# Altium schematic sheet Power-Supplies.SchDoc(Power-Supplies)
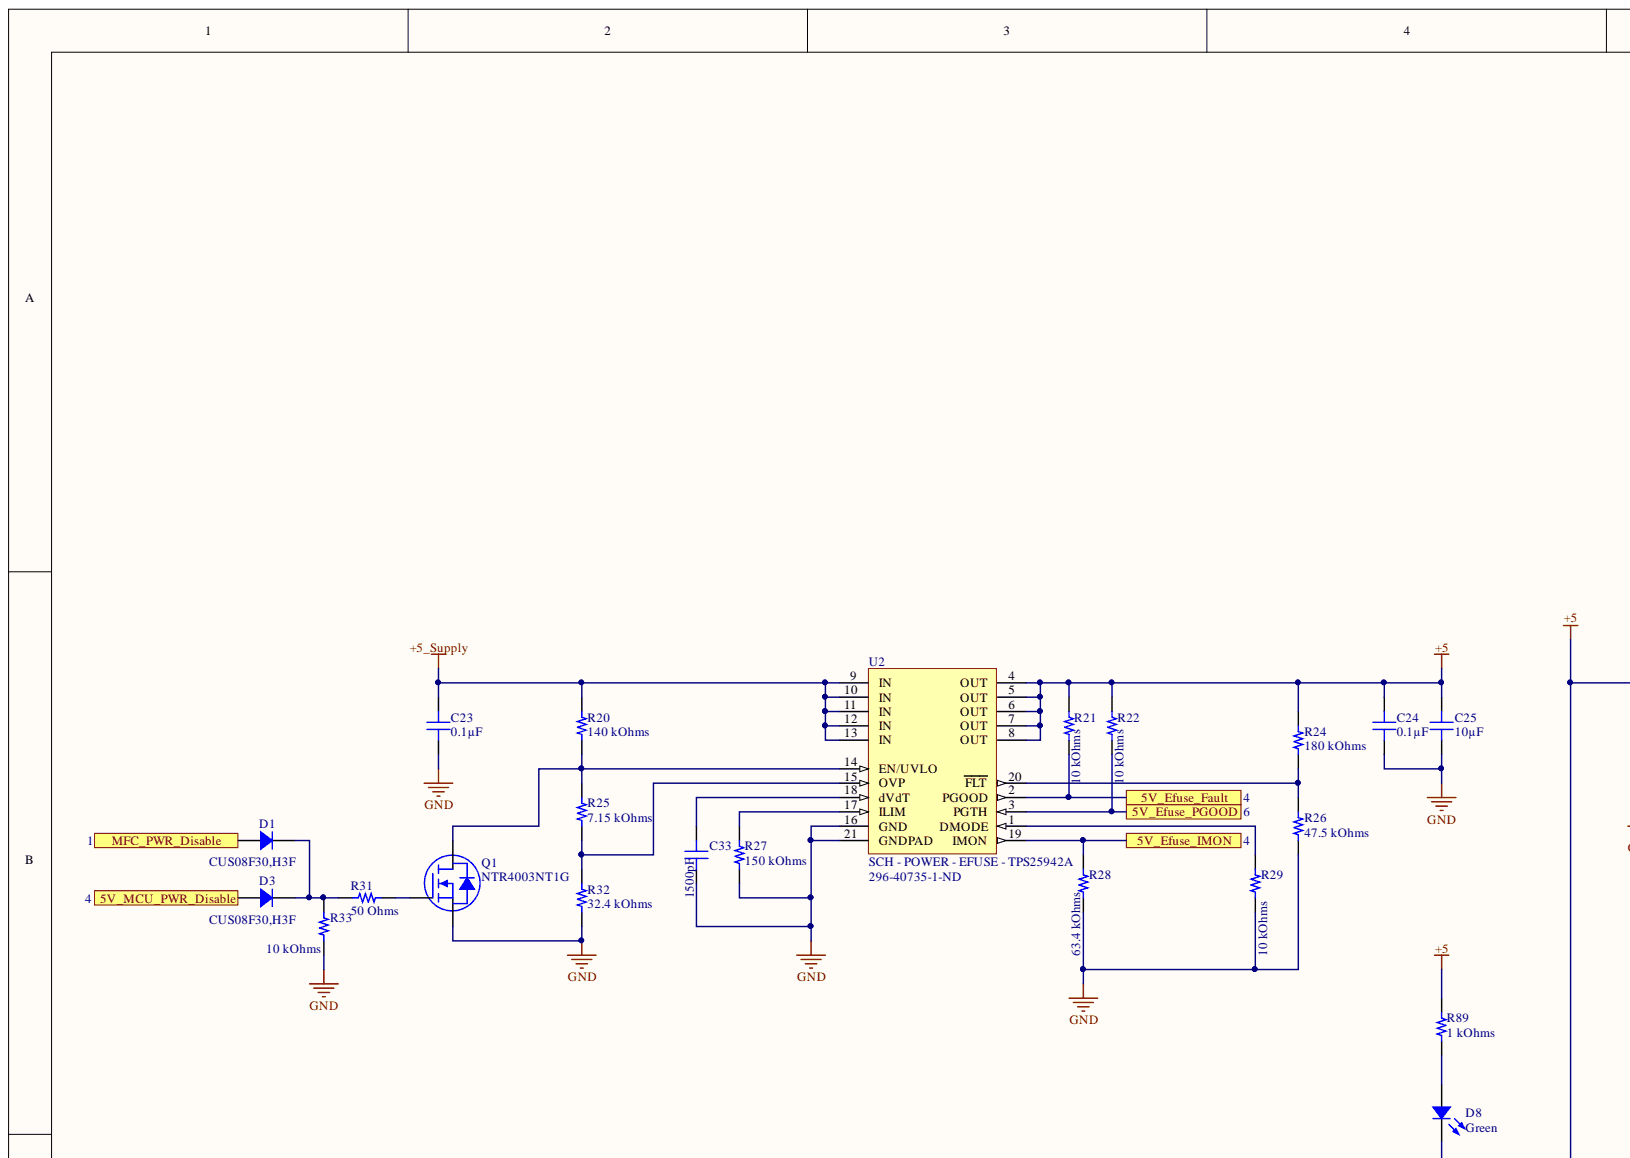
[diagram p0: Power-Supplies.SchDoc, top left]
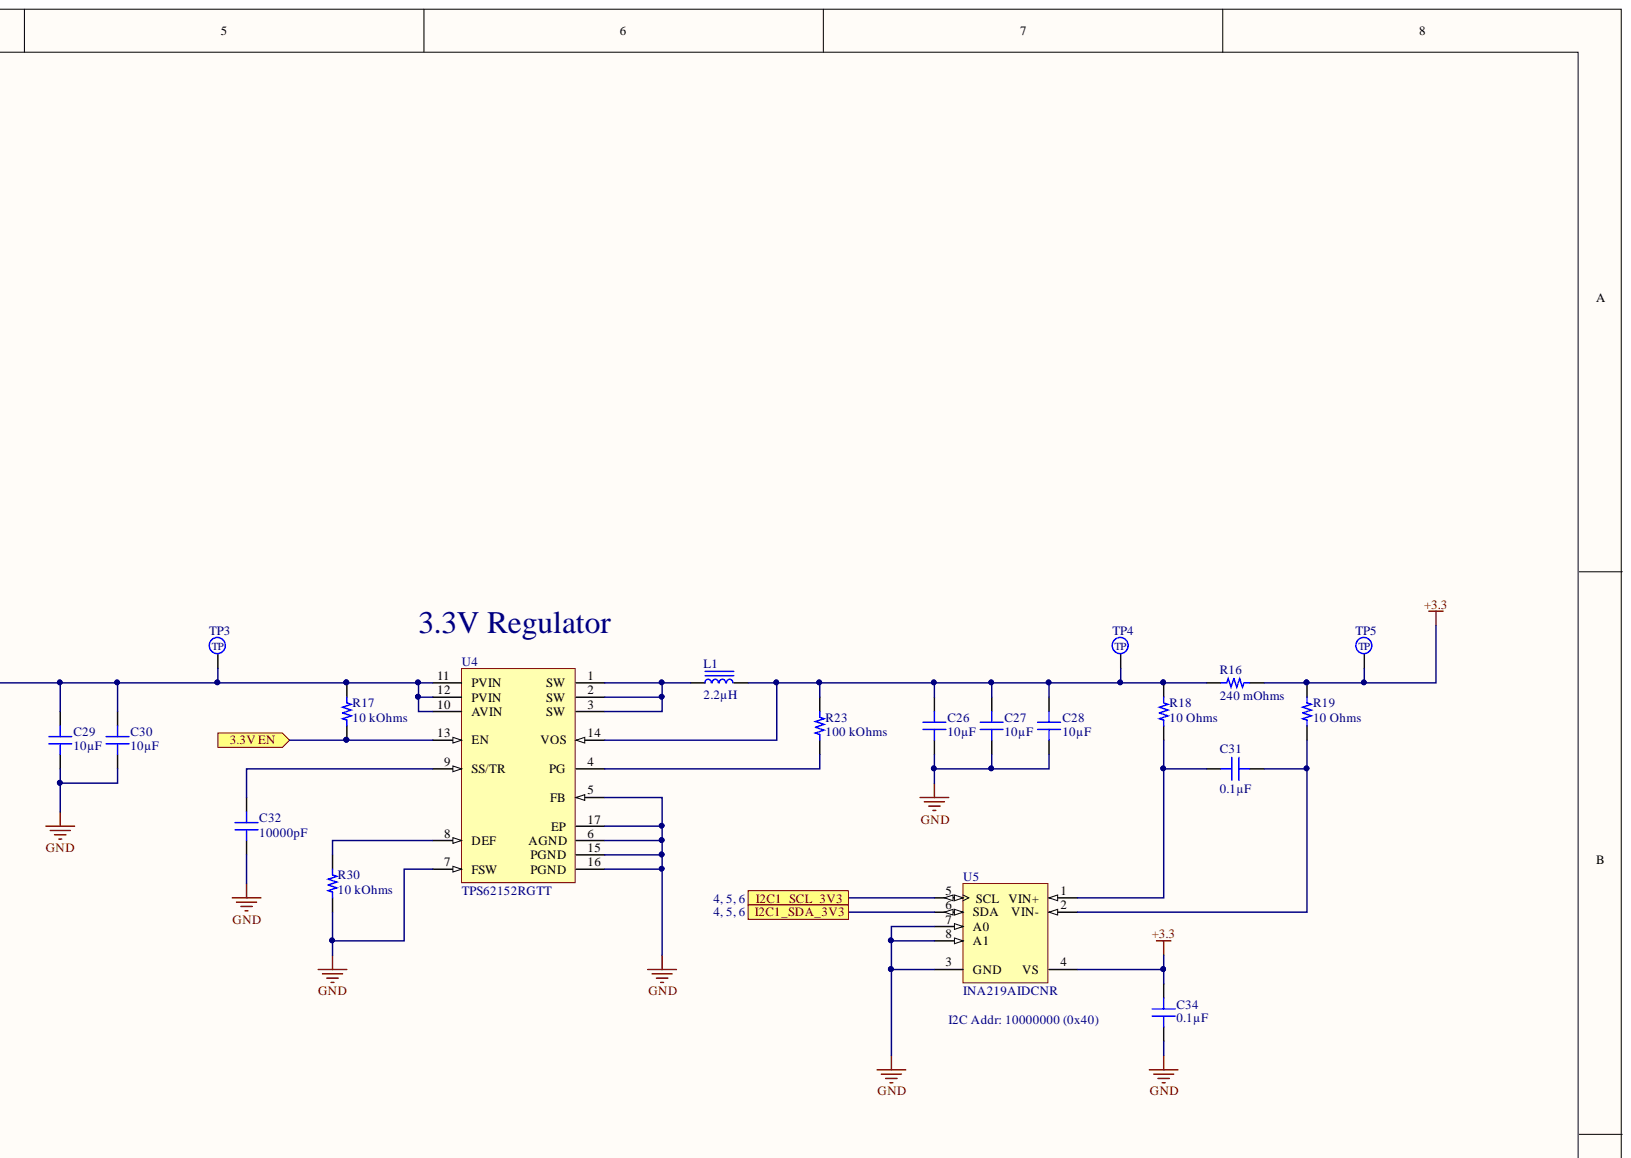
[diagram p1: Power-Supplies.SchDoc, top right]
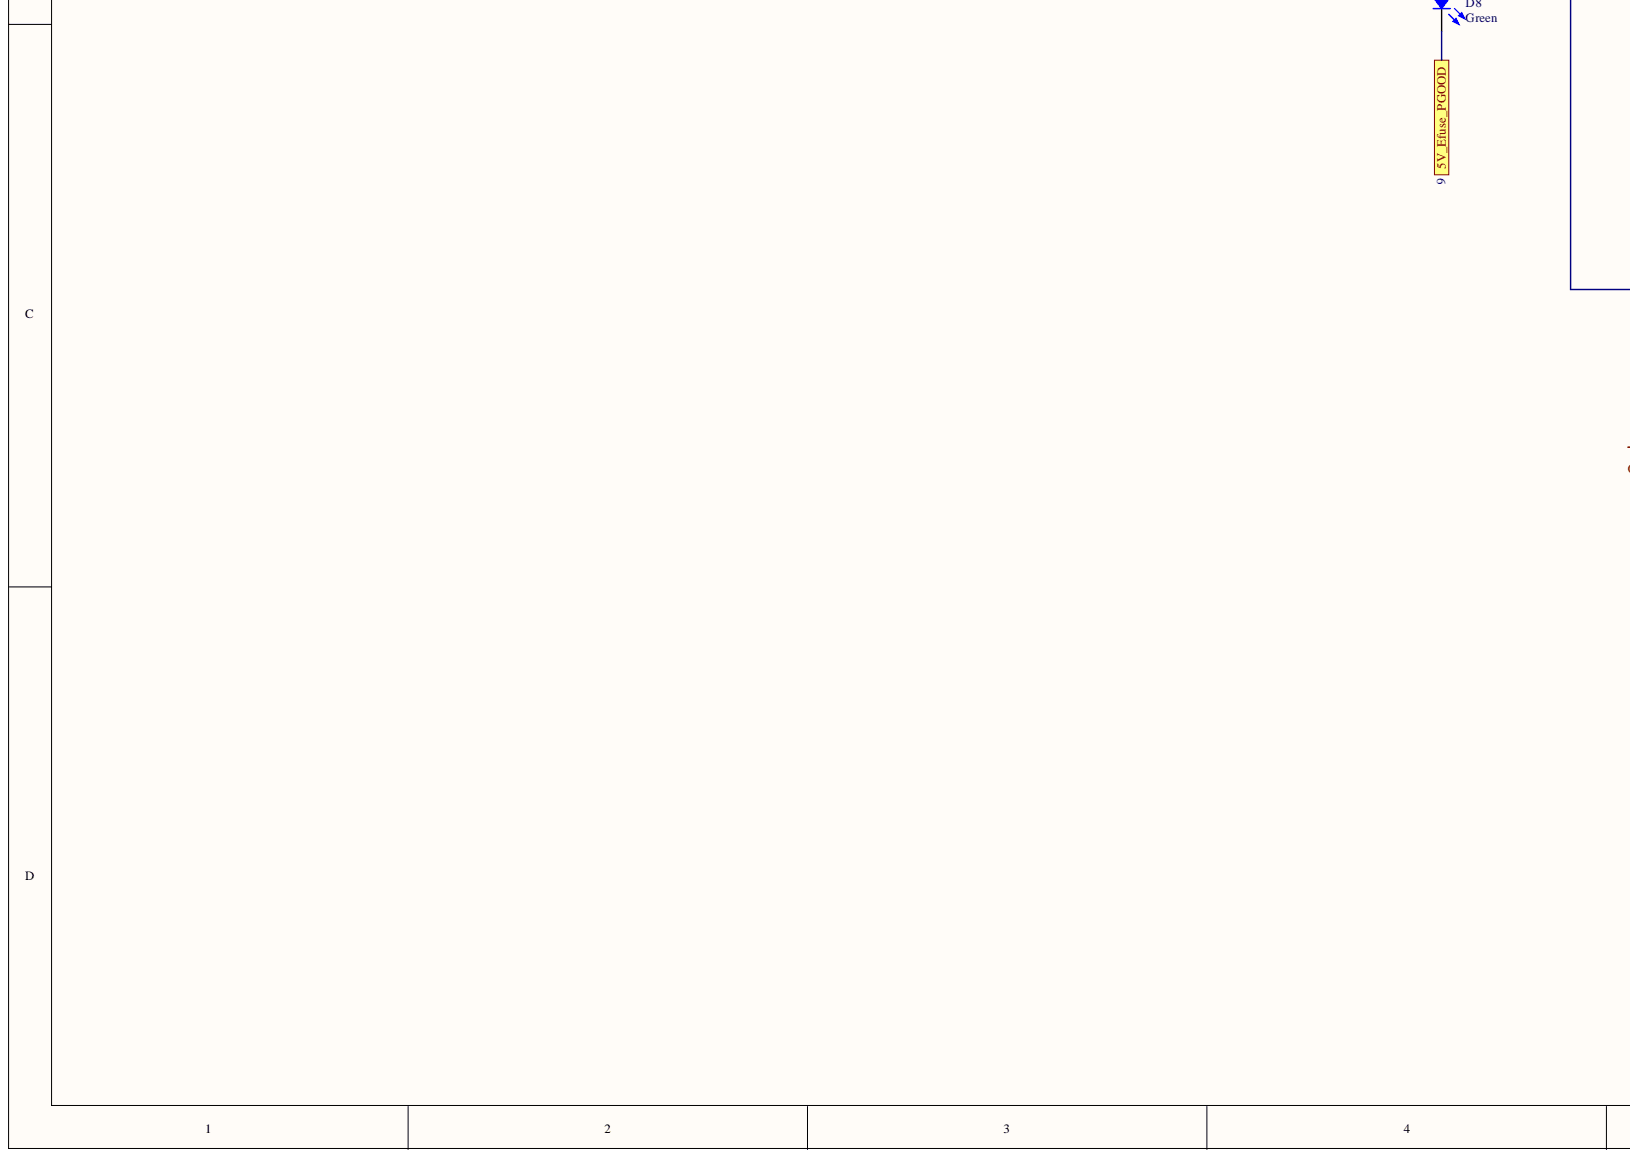
[diagram p2: Power-Supplies.SchDoc, bottom left]
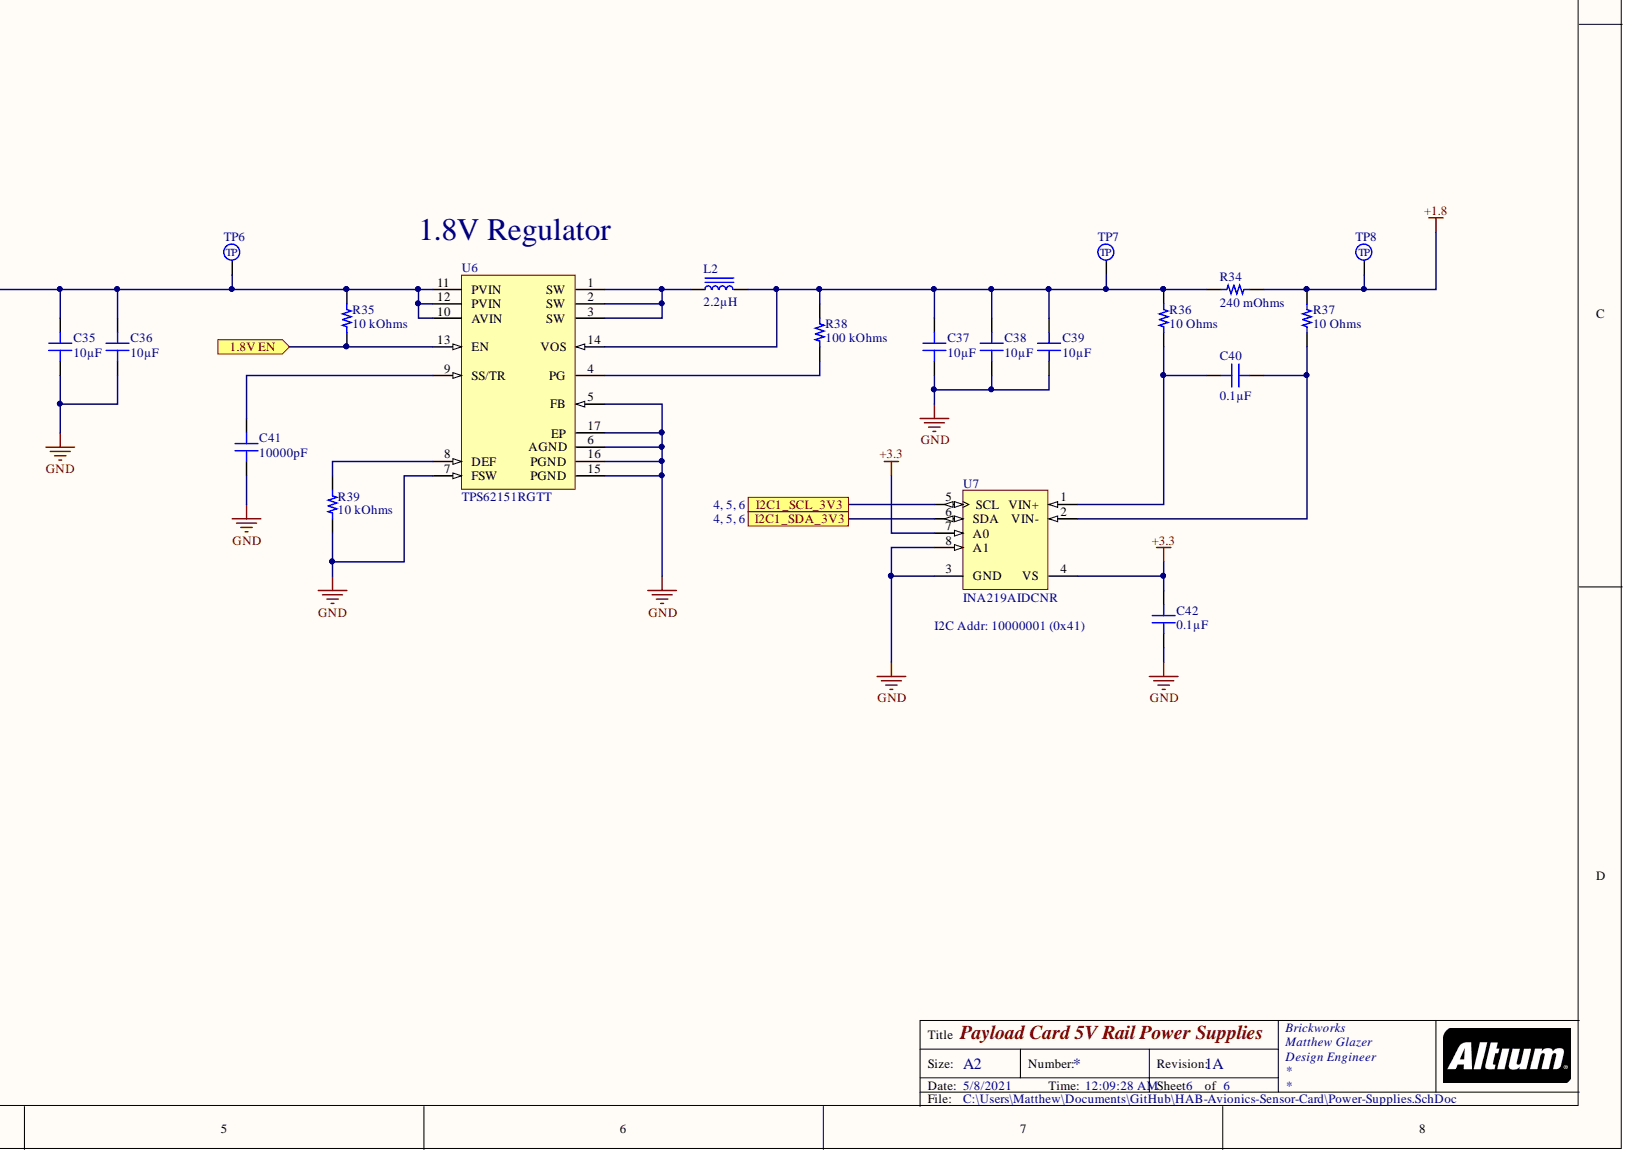
[diagram p3: Power-Supplies.SchDoc, bottom right]

## Parts
C23  0.1µF  [CAP 0603_1608]
C24  0.1µF  [CAP 0603_1608]
C25  10µF  [CAP 0805_2012]
C26  10µF  [CAP 0805_2012]
C27  10µF  [CAP 0805_2012]
C28  10µF  [CAP 0805_2012]
C29  10µF  [CAP 0805_2012]
C30  10µF  [CAP 0805_2012]
C31  0.1µF  [CAP 0603_1608]
C32  10000pF  [CAP 0603_1608]
C33  1500pF  [CAP 0603_1608]
C34  0.1µF  [CAP 0603_1608]
C35  10µF  [CAP 0805_2012]
C36  10µF  [CAP 0805_2012]
C37  10µF  [CAP 0805_2012]
C38  10µF  [CAP 0805_2012]
C39  10µF  [CAP 0805_2012]
C40  0.1µF  [CAP 0603_1608]
C41  10000pF  [CAP 0603_1608]
C42  0.1µF  [CAP 0603_1608]
D1  CUS08F30,H3F  [SOD323]
D3  CUS08F30,H3F  [SOD323]
D8  Green  [LED 0603_1608 GREEN]
L1  2.2µH  [BOURNS SRN4018]
L2  2.2µH  [BOURNS SRN4018]
Q1  NTR4003NT1G  [ON SEMI SOT-23-3]
R16  240 mOhms  [RES 0805_2012]
R17  10 kOhms  [RES 0603_1608]
R18  10 Ohms  [RES 0603_1608]
R19  10 Ohms  [RES 0603_1608]
R20  140 kOhms  [RES 0603_1608]
R21  10 kOhms  [RES 0603_1608]
R22  10 kOhms  [RES 0603_1608]
R23  100 kOhms  [RES 0603_1608]
R24  180 kOhms  [RES 0603_1608]
R25  7.15 kOhms  [RES 0603_1608]
R26  47.5 kOhms  [RES 0603_1608]
R27  150 kOhms  [RES 0603_1608]
R28  63.4 kOhms  [RES 0603_1608]
R29  10 kOhms  [RES 0603_1608]
R30  10 kOhms  [RES 0603_1608]
R31  50 Ohms  [RES 0603_1608]
R32  32.4 kOhms  [RES 0603_1608]
R33  10 kOhms  [RES 0603_1608]
R34  240 mOhms  [RES 0805_2012]
R35  10 kOhms  [RES 0603_1608]
R36  10 Ohms  [RES 0603_1608]
R37  10 Ohms  [RES 0603_1608]
R38  100 kOhms  [RES 0603_1608]
R39  10 kOhms  [RES 0603_1608]
R89  1 kOhms  [RES 0603_1608]
TP3  2.5mm_SMD_TestPoint  [2.5mm TestPoint]  (value not drawn)
TP4  2.5mm_SMD_TestPoint  [2.5mm TestPoint]  (value not drawn)
TP5  2.5mm_SMD_TestPoint  [2.5mm TestPoint]  (value not drawn)
TP6  2.5mm_SMD_TestPoint  [2.5mm TestPoint]  (value not drawn)
TP7  2.5mm_SMD_TestPoint  [2.5mm TestPoint]  (value not drawn)
TP8  2.5mm_SMD_TestPoint  [2.5mm TestPoint]  (value not drawn)
U2  SCH - POWER - EFUSE - TPS25942A  Supplier Part Number 1: 296-40735-1-ND  [PCB - LEADLESS - QFN - TI 20-WQFN]
U4  TPS62152RGTT  [RGT0016C_V]
U5  INA219AIDCNR  [DCN0008A_M]
U6  TPS62151RGTT  [RGT0016C_V]
U7  INA219AIDCNR  [DCN0008A_M]

## Ports
1.8V EN, 3.3V EN, 5V_Efuse_Fault, 5V_Efuse_IMON, 5V_Efuse_PGOOD, 5V_MCU_PWR_Disable, I2C1_SCL_3V3, I2C1_SDA_3V3, MFC_PWR_Disable

## Nets
+1.8: R34.2 R37.2 TP8.1
+3.3: C34.2 C42.2 R16.2 R19.2 TP5.1 U5.4(VS) U7.4(VS) U7.7(A0)
+5: C24.2 C25.2 C29.2 C30.2 C35.2 C36.2 R17.2 R21.2 R22.2 R24.2 R35.2 R89.1 TP3.1 TP6.1 U2.4(OUT) U2.5(OUT) U2.6(OUT) U2.7(OUT) U2.8(OUT) U4.10(AVIN) U4.11(PVIN) U4.12(PVIN) U6.10(AVIN) U6.11(PVIN) U6.12(PVIN)
+5_Supply: C23.2 R20.2 U2.9(IN) U2.10(IN) U2.11(IN) U2.12(IN) U2.13(IN)
GND: C23.1 C24.1 C25.1 C26.1 C27.1 C28.1 C29.1 C30.1 C32.1 C33.1 C34.1 C35.1 C36.1 C37.1 C38.1 C39.1 C41.1 C42.1 Q1.2 R26.1 R27.1 R28.1 R29.1 R30.1 R32.1 R33.1 R39.1 U2.16(GND) U2.21(GNDPAD) U4.5(FB) U4.6(AGND) U4.7(FSW) U4.15(PGND) U4.16(PGND) U4.17(EP) U5.3(GND) U5.7(A0) U5.8(A1) U6.5(FB) U6.6(AGND) U6.7(FSW) U6.15(PGND) U6.16(PGND) U6.17(EP) U7.3(GND) U7.8(A1)
1.8V EN: R35.1 U6.13(EN) ; port 1.8V EN
3.3V EN: R17.1 U4.13(EN) ; port 3.3V EN
5V_Efuse_Fault: R21.1 U2.2(PGOOD) ; port 5V_Efuse_Fault
5V_Efuse_IMON: R28.2 U2.19(IMON) ; port 5V_Efuse_IMON
5V_Efuse_PGOOD: D8.2 R22.1 U2.3(PGTH) ; port 5V_Efuse_PGOOD
5V_MCU_PWR_Disable: D3.1 ; port 5V_MCU_PWR_Disable
I2C1_SCL_3V3: U5.5(SCL) U7.5(SCL) ; port I2C1_SCL_3V3
I2C1_SDA_3V3: U5.6(SDA) U7.6(SDA) ; port I2C1_SDA_3V3
MFC_PWR_Disable: D1.1 ; port MFC_PWR_Disable
NetC26_2: C26.2 C27.2 C28.2 L1.2 R16.1 R18.2 R23.2 TP4.1 U4.14(VOS)
NetC31_1: C31.1 R19.1 U5.2(VIN-)
NetC31_2: C31.2 R18.1 U5.1(VIN+)
NetC32_2: C32.2 U4.9(SS/TR)
NetC33_2: C33.2 U2.18(dVdT)
NetC37_2: C37.2 C38.2 C39.2 L2.2 R34.1 R36.2 R38.2 TP7.1 U6.14(VOS)
NetC40_1: C40.1 R37.1 U7.2(VIN-)
NetC40_2: C40.2 R36.1 U7.1(VIN+)
NetC41_2: C41.2 U6.9(SS/TR)
NetD1_2: D1.2 D3.2 R31.2 R33.2
NetD8_1: D8.1 R89.2
NetL1_1: L1.1 U4.1(SW) U4.2(SW) U4.3(SW)
NetL2_1: L2.1 U6.1(SW) U6.2(SW) U6.3(SW)
NetQ1_1: Q1.1 R31.1
NetQ1_3: Q1.3 R20.1 R25.2 U2.14(EN/UVLO)
NetR23_1: R23.1 U4.4(PG)
NetR24_1: R24.1 R26.2 U2.20(FLT)
NetR25_1: R25.1 R32.2 U2.15(OVP)
NetR27_2: R27.2 U2.17(ILIM)
NetR29_2: R29.2 U2.1(DMODE)
NetR30_2: R30.2 U4.8(DEF)
NetR38_1: R38.1 U6.4(PG)
NetR39_2: R39.2 U6.8(DEF)

## Library data of the parts
C23 C24 C31 C34 C40 C42 = CL10B104KA8WPNC: CAP CER 0.1UF 25V X7R 0603; Manufacturer: Samsung Electro-Mechanics; Tolerance: ±10%; Database Table Name: Capacitors - Ceramic - 0603; Voltage - Rated: 25V; Value: 0.1µF; Thickness (Max): 0.035" (0.90mm); Temperature Coefficient: X7R; Supplier Part Number 1: 1276-6717-1-ND; Supplier 1: DigiKey; Size / Dimension: 0.063" L x 0.031" W (1.60mm x 0.80mm); Series: CL; Ratings: AEC-Q200; Price: 0.02; Part Status: Active; Part Number: CL10B104KA8WPNC; PartId: 5631; Packaging: TapeAndReel; Package / Case: 0603 (1608 Metric); Operating Temperature: -55°C ~ 125°C; Mounting Type: Surface Mount, MLCC; Minimum Order: 1; Lifecycle Status: Active; Library Name: Celestial Altium Library - altium_library.DbLib; LastUpdated: 9/2/2020 1:03:30 AM; Features: Open Mode; Device Package: 0603 (1608 Metric); ComponentLink2URL: http://digikey.com/product-detail/en/samsung-electro-mechanics/CL10B104KA8WPNC/1276-6717-1-ND/5961576; ComponentLink2Description: DigiKey Link; ComponentLink1URL: http://www.samsungsem.com/kr/support/product-search/mlcc/CL10B104KA8WPNC.jsp; ComponentLink1Description: Datasheet; Component Kind: Standard; Capacitance: 0.1µF; Applications: Automotive
C25-C30 C35-C39 = CC0805KKX5R7BB106: CAP CER 10UF 16V X5R 0805; Manufacturer: Yageo; Tolerance: ±10%; Database Table Name: Capacitors - Ceramic - 0805; Voltage - Rated: 16V; Value: 10µF; Thickness (Max): 0.057" (1.45mm); Temperature Coefficient: X5R; Supplier Part Number 1: 311-1865-1-ND; Supplier 1: DigiKey; Size / Dimension: 0.079" L x 0.049" W (2.00mm x 1.25mm); Series: CC; Price: 0.09; Part Status: Active; Part Number: CC0805KKX5R7BB106; PartId: 8867; Packaging: TapeAndReel; Package / Case: 0805 (2012 Metric); Operating Temperature: -55°C ~ 85°C; Mounting Type: Surface Mount, MLCC; Minimum Order: 1; Lifecycle Status: Active; Library Name: Celestial Altium Library - altium_library.DbLib; LastUpdated: 9/2/2020 1:04:30 AM; Device Package: 0805 (2012 Metric); ComponentLink2URL: http://digikey.com/product-detail/en/yageo/CC0805KKX5R7BB106/311-1865-1-ND/5195767; ComponentLink2Description: DigiKey Link; ComponentLink1URL: https://www.yageo.com/upload/media/product/productsearch/datasheet/mlcc/UPY-GPHC_X5R_4V-to-50V_25.pdf; ComponentLink1Description: Datasheet; Component Kind: Standard; Capacitance: 10µF; Applications: General Purpose
C32 C41 = C0603S103K4RACTU: CAP CER 10000PF 16V X7R 0603; Manufacturer: KEMET; Tolerance: ±10%; Database Table Name: Capacitors - Ceramic - 0603; Voltage - Rated: 16V; Value: 10000pF; Thickness (Max): 0.034" (0.87mm); Temperature Coefficient: X7R; Supplier Part Number 1: 399-9135-1-ND; Supplier 1: DigiKey; Size / Dimension: 0.063" L x 0.031" W (1.60mm x 0.80mm); Series: SMD Comm X7R FE; Price: 0.07; Part Status: Active; Part Number: C0603S103K4RACTU; PartId: 3312; Packaging: TapeAndReel; Package / Case: 0603 (1608 Metric); Operating Temperature: -55°C ~ 125°C; Mounting Type: Surface Mount, MLCC; Minimum Order: 1; Lifecycle Status: Active; Library Name: Celestial Altium Library - altium_library.DbLib; LastUpdated: 9/2/2020 1:03:34 AM; Features: Floating Electrode; Device Package: 0603 (1608 Metric); ComponentLink2URL: http://digikey.com/product-detail/en/kemet/C0603S103K4RACTU/399-9135-1-ND/3522653; ComponentLink2Description: DigiKey Link; ComponentLink1URL: https://api.kemet.com/component-edge/download/datasheet/C0603S103K4RACTU.pdf; ComponentLink1Description: Datasheet; Component Kind: Standard; Capacitance: 10000pF; Applications: Boardflex Sensitive
C33 = CC0603JRX7R8BB152: CAP CER 1500PF 25V X7R 0603; Manufacturer: Yageo; Tolerance: ±5%; Database Table Name: Capacitors - Ceramic - 0603; Voltage - Rated: 25V; Value: 1500pF; Thickness (Max): 0.035" (0.90mm); Temperature Coefficient: X7R; Supplier Part Number 1: 311-3996-1-ND; Supplier 1: DigiKey; Size / Dimension: 0.063" L x 0.031" W (1.60mm x 0.80mm); Series: CC; Price: 0.02; Part Status: Active; Part Number: CC0603JRX7R8BB152; PartId: 12307; Packaging: TapeAndReel; Package / Case: 0603 (1608 Metric); Operating Temperature: -55°C ~ 125°C; Mounting Type: Surface Mount, MLCC; Minimum Order: 1; Lifecycle Status: Active; Library Name: Celestial Altium Library - altium_library.DbLib; LastUpdated: 9/2/2020 1:03:18 AM; Device Package: 0603 (1608 Metric); ComponentLink2URL: http://digikey.com/product-detail/en/yageo/CC0603JRX7R8BB152/311-3996-1-ND/8025085; ComponentLink2Description: DigiKey Link; ComponentLink1URL: https://www.yageo.com/upload/media/product/productsearch/datasheet/mlcc/UPY-GPHC_X7R_6.3V-to-50V_18.pdf; ComponentLink1Description: Datasheet; Component Kind: Standard; Capacitance: 1500pF; Applications: General Purpose
D1 D3 = CUS08F30,H3F: DIODE SCHOTTKY 30V 800MA USC; Manufacturer: Toshiba Semiconductor and Storage; Voltage - Forward (Vf) (Max) @ If: 220mV @ 10mA; Speed: Fast Recovery =< 500ns, > 200mA (Io); Database Table Name: Diodes - Rectifiers - Single; Current - Reverse Leakage @ Vr: 50µA @ 30V; Voltage - DC Reverse (Vr) (Max): 30V; Supplier Part Number 1: CUS08F30H3FCT-ND; Supplier Device Package: USC; Supplier 1: DigiKey; Series: -; Price: 0.06; Part Status: Active; Part Number: CUS08F30,H3F; PartId: 3013; Packaging: TapeAndReel; Package / Case: SC-76, SOD-323; Operating Temperature - Junction: 125°C (Max); Mounting Type: Surface Mount; Minimum Order: 1; Lifecycle Status: Active; Library Name: Celestial Altium Library - altium_library.DbLib; LastUpdated: 9/2/2020 1:27:26 AM; Diode Type: Schottky; Current - Average Rectified (Io): 800mA; ComponentLink2URL: http://digikey.com/product-detail/en/toshiba-semiconductor-and-storage/CUS08F30H3F/CUS08F30H3FCT-ND/5114376; ComponentLink2Description: DigiKey Link; ComponentLink1URL: https://toshiba.semicon-storage.com/info/docget.jsp?did=9019&prodName=CUS08F30; ComponentLink1Description: Datasheet; Component Kind: Standard
D8 = LTST-C193KGKT-5A: LED GREEN CLEAR CHIP SMD; Manufacturer: Lite-On Inc.; Wavelength - Peak: 574nm; Wavelength - Dominant: 572nm; Voltage - Forward (Vf) (Typ): 2V; Viewing Angle: 130°; Millicandela Rating: 16.25mcd; Lens Style: Rectangle with Flat Top; Lens Size: 1.15mm x 0.80mm; Lens Color: Colorless; Database Table Name: LED - Indication; Current - Test: 5mA; Configuration: Standard; Value: Green; Supplier Part Number 1: 160-1828-1-ND; Supplier Device Package: Chip LED; Supplier 1: DigiKey; Size / Dimension: 1.60mm L x 0.80mm W; Series: -; Price: 0.06; Part Status: Active; Part Number: LTST-C193KGKT-5A; PartId: 694; Packaging: TapeAndReel; Package / Case: 0603 (1608 Metric); Mounting Type: Surface Mount; Minimum Order: 1; Lifecycle Status: Active; Library Name: Celestial Altium Library - altium_library.DbLib; Lens Transparency: Clear; LastUpdated: 9/2/2020 1:20:41 AM; Height (Max): 0.45mm; Device Package: 0603 (1608 Metric); ComponentLink2URL: http://digikey.com/product-detail/en/lite-on-inc/LTST-C193KGKT-5A/160-1828-1-ND/2356247; ComponentLink2Description: DigiKey Link; ComponentLink1URL: http://optoelectronics.liteon.com/upload/download/DS22-2007-0094/LTST-C193KGKT-5A.PDF; ComponentLink1Description: Datasheet; Component Kind: Standard; Color: Green
L1 L2 = SRN4018-2R2M: FIXED IND 2.2UH 2.9A 44 MOHM SMD; Manufacturer: Bourns Inc.; Type: Wirewound; Tolerance: ±20%; Shielding: Semi-Shielded; Q @ Freq: 9 @ 7.96MHz; Material - Core: Ferrite; Inductance Frequency - Test: 100kHz; Frequency - Self Resonant: 60MHz; DC Resistance (DCR): 44mOhm Max; Database Table Name: Inductors - Power; Current - Saturation: 3A; Value: 2.2µH; Supplier Part Number 1: SRN4018-2R2MCT-ND; Supplier 1: DigiKey; Size / Dimension: 0.157" L x 0.157" W (4.00mm x 4.00mm); Series: SRN4018; Price: 0.16; Part Status: Active; Part Number: SRN4018-2R2M; PartId: 3660; Packaging: TapeAndReel; Package / Case: Nonstandard; Operating Temperature: -40°C ~ 125°C; Mounting Type: Surface Mount; Minimum Order: 1; Lifecycle Status: Active; Library Name: Celestial Altium Library - altium_library.DbLib; LastUpdated: 9/2/2020 1:30:23 AM; Inductance: 2.2µH; Height - Seated (Max): 0.071" (1.80mm); Device Package: Nonstandard; Current Rating (Amps): 2.9A; ComponentLink2URL: http://digikey.com/product-detail/en/bourns-inc/SRN4018-2R2M/SRN4018-2R2MCT-ND/3821517; ComponentLink2Description: DigiKey Link; ComponentLink1URL: https://www.bourns.com/docs/Product-Datasheets/SRN4018.pdf; ComponentLink1Description: Datasheet; Component Kind: Standard
Q1 = NTR4003NT1G: MOSFET N-CH 30V 500MA SOT-23; Manufacturer: ON Semiconductor; Vgs(th) (Max) @ Id: 1.4V @ 250µA; Vgs (Max): ±20V; Rds On (Max) @ Id, Vgs: 1.5Ohm @ 10mA, 4V; Power Dissipation (Max): 690mW (Ta); Input Capacitance (Ciss) (Max) @ Vds: 21pF @ 5V; Gate Charge (Qg) (Max) @ Vgs: 1.15nC @ 5V; FET Type: N-Channel; Drive Voltage (Max Rds On,  Min Rds On): 2.5V, 4V; Database Table Name: MOSFET - Single - N-Ch; Current - Continuous Drain (Id) @ 25°C: 500mA (Ta); Technology: MOSFET (Metal Oxide); Supplier Part Number 1: NTR4003NT1GOSCT-ND; Supplier Device Package: SOT-23-3 (TO-236); Supplier 1: DigiKey; Series: -; Price: 0.05; Part Status: Active; Part Number: NTR4003NT1G; Packaging: TapeAndReel; Package / Case: TO-236-3, SC-59, SOT-23-3; Operating Temperature: -55°C ~ 150°C (TJ); Mounting Type: Surface Mount; Minimum Order: 1; Lifecycle Status: Active; Library Name: Celestial Altium Library - altium_library.DbLib; LastUpdated: 9/2/2020 1:25:08 AM; Drain to Source Voltage (Vdss): 30V; ComponentLink2URL: http://digikey.com/product-detail/en/on-semiconductor/NTR4003NT1G/NTR4003NT1GOSCT-ND/1967270; ComponentLink2Description: DigiKey Link; ComponentLink1URL: http://www.onsemi.com/pub/Collateral/NTR4003N-D.PDF; ComponentLink1Description: Datasheet; Component Kind: Standard
R16 R34 = ERJ-6DQFR24V: RES 0.24 OHM 1% 1/2W 0805; Manufacturer: Panasonic Electronic Components; Temperature Coefficient: ±100ppm/°C; Power (Watts): 0.5W, 1/2W; Database Table Name: Resistors - Surface Mount - 0805; Value: 240 mOhms; Tolerance: ±1%; Supplier Part Number 1: P19293CT-ND; Supplier Device Package: 0805; Supplier 1: DigiKey; Size / Dimension: 0.079" L x 0.049" W (2.00mm x 1.25mm); Series: ERJ; Resistance: 240 mOhms; Ratings: AEC-Q200; Price: 0.04; Part Status: Active; Part Number: ERJ-6DQFR24V; PartId: 39224; Packaging: TapeAndReel; Package / Case: 0805 (2012 Metric); Operating Temperature: -55°C ~ 155°C; Number of Terminations: 2; Minimum Order: 1; Lifecycle Status: Active; Library Name: Celestial Altium Library - altium_library.DbLib; LastUpdated: 9/2/2020 1:14:59 AM; Height - Seated (Max): 0.028" (0.70mm); Features: Automotive AEC-Q200, Current Sense; Device Package: 0805 (2012 Metric); Composition: Thick Film; ComponentLink2URL: http://digikey.com/product-detail/en/panasonic-electronic-components/ERJ-6DQFR24V/P19293CT-ND/6004648; ComponentLink2Description: DigiKey Link; ComponentLink1URL: https://industrial.panasonic.com/cdbs/www-data/pdf/RDN0000/AOA0000C313.pdf; ComponentLink1Description: Datasheet; Component Kind: Standard
R17 R21 R22 R29 R30 R33 R35 R39 = RMCF0603JT10K0: RES 10K OHM 5% 1/10W 0603; Manufacturer: Stackpole Electronics Inc; Temperature Coefficient: ±200ppm/°C; Power (Watts): 0.1W, 1/10W; Database Table Name: Resistors - Surface Mount - 0603; Value: 10 kOhms; Tolerance: ±5%; Supplier Part Number 1: RMCF0603JT10K0CT-ND; Supplier Device Package: 0603; Supplier 1: DigiKey; Size / Dimension: 0.061" L x 0.031" W (1.55mm x 0.80mm); Series: RMCF; Resistance: 10 kOhms; Ratings: AEC-Q200; Price: 0.10; Part Status: Active; Part Number: RMCF0603JT10K0; PartId: 25659; Packaging: CutTape; Package / Case: 0603 (1608 Metric); Operating Temperature: -55°C ~ 155°C; Number of Terminations: 2; Minimum Order: 1; Lifecycle Status: Active; Library Name: Celestial Altium Library - altium_library.DbLib; LastUpdated: 8/30/2020 8:48:45 PM; Height - Seated (Max): 0.022" (0.55mm); Features: Automotive AEC-Q200; Device Package: 0603 (1608 Metric); Composition: Thick Film; ComponentLink2URL: http://digikey.com/product-detail/en/stackpole-electronics-inc/RMCF0603JT10K0/RMCF0603JT10K0CT-ND/1943191; ComponentLink2Description: DigiKey Link; ComponentLink1URL: https://www.seielect.com/catalog/sei-packaging.pdf; ComponentLink1Description: Datasheet; Component Kind: Standard
R18 R19 R36 R37 = CRGCQ0603F10R: CRGCQ 0603 10R 1%; Manufacturer: TE Connectivity Passive Product; Temperature Coefficient: ±400ppm/°C; Power (Watts): 0.1W, 1/10W; Database Table Name: Resistors - Surface Mount - 0603; Value: 10 Ohms; Tolerance: ±1%; Supplier Part Number 1: A129664CT-ND; Supplier Device Package: 0603; Supplier 1: DigiKey; Size / Dimension: 0.063" L x 0.031" W (1.60mm x 0.80mm); Series: CRGCQ; Resistance: 10 Ohms; Ratings: AEC-Q200; Price: 0.10; Part Status: Active; Part Number: CRGCQ0603F10R; PartId: 23975; Packaging: CutTape; Package / Case: 0603 (1608 Metric); Operating Temperature: -55°C ~ 155°C; Number of Terminations: 2; Minimum Order: 1; Lifecycle Status: Active; Library Name: Celestial Altium Library - altium_library.DbLib; LastUpdated: 9/2/2020 1:09:39 AM; Height - Seated (Max): 0.022" (0.55mm); Features: Automotive AEC-Q200, Moisture Resistant; Device Package: 0603 (1608 Metric); Composition: Thick Film; ComponentLink2URL: http://digikey.com/product-detail/en/te-connectivity-passive-product/CRGCQ0603F10R/A129664CT-ND/8577496; ComponentLink2Description: DigiKey Link; ComponentLink1URL: https://www.te.com/commerce/DocumentDelivery/DDEController?Action=srchrtrv&DocNm=1773204-3&DocType=DS&DocLang=English; ComponentLink1Description: Datasheet; Component Kind: Standard
R20 = RT0603BRE07140KL: RES SMD 140K OHM 0.1% 1/10W 0603; Manufacturer: Yageo; Temperature Coefficient: ±50ppm/°C; Power (Watts): 0.1W, 1/10W; Database Table Name: Resistors - Surface Mount - 0603; Value: 140 kOhms; Tolerance: ±0.1%; Supplier Part Number 1: YAG4626CT-ND; Supplier Device Package: 0603; Supplier 1: DigiKey; Size / Dimension: 0.063" L x 0.031" W (1.60mm x 0.80mm); Series: RT; Resistance: 140 kOhms; Price: 0.05; Part Status: Active; Part Number: RT0603BRE07140KL; PartId: 22168; Packaging: TapeAndReel; Package / Case: 0603 (1608 Metric); Operating Temperature: -55°C ~ 155°C; Number of Terminations: 2; Minimum Order: 1; Lifecycle Status: Active; Library Name: Celestial Altium Library - altium_library.DbLib; LastUpdated: 9/2/2020 1:09:47 AM; Height - Seated (Max): 0.022" (0.55mm); Device Package: 0603 (1608 Metric); Composition: Thin Film; ComponentLink2URL: http://digikey.com/product-detail/en/yageo/RT0603BRE07140KL/YAG4626CT-ND/6616782; ComponentLink2Description: DigiKey Link; ComponentLink1URL: https://www.yageo.com/upload/media/product/productsearch/datasheet/rchip/PYu-RT_1-to-0.01_RoHS_L_11.pdf; ComponentLink1Description: Datasheet; Component Kind: Standard
R23 R38 = RC0603JR-10100KL: RES SMD 100K OHM 5% 1/10W 0603; Manufacturer: Yageo; Temperature Coefficient: ±100ppm/°C; Power (Watts): 0.1W, 1/10W; Database Table Name: Resistors - Surface Mount - 0603; Value: 100 kOhms; Tolerance: ±5%; Supplier Part Number 1: YAG2294CT-ND; Supplier Device Package: 0603; Supplier 1: DigiKey; Size / Dimension: 0.063" L x 0.031" W (1.60mm x 0.80mm); Series: RC; Resistance: 100 kOhms; Price: 0.10; Part Status: Active; Part Number: RC0603JR-10100KL; PartId: 6071; Packaging: CutTape; Package / Case: 0603 (1608 Metric); Operating Temperature: -55°C ~ 155°C; Number of Terminations: 2; Minimum Order: 1; Lifecycle Status: Active; Library Name: Celestial Altium Library - altium_library.DbLib; LastUpdated: 9/2/2020 1:10:22 AM; Height - Seated (Max): 0.022" (0.55mm); Features: Moisture Resistant; Device Package: 0603 (1608 Metric); Composition: Thick Film; ComponentLink2URL: http://digikey.com/product-detail/en/yageo/RC0603JR-10100KL/YAG2294CT-ND/5252408; ComponentLink2Description: DigiKey Link; ComponentLink1URL: https://www.yageo.com/upload/media/product/productsearch/datasheet/rchip/PYu-RC_Group_51_RoHS_L_10.pdf; ComponentLink1Description: Datasheet; Component Kind: Standard
R24 = RMCF0603FT180K: RES 180K OHM 1% 1/10W 0603; Manufacturer: Stackpole Electronics Inc; Temperature Coefficient: ±100ppm/°C; Power (Watts): 0.1W, 1/10W; Database Table Name: Resistors - Surface Mount - 0603; Value: 180 kOhms; Tolerance: ±1%; Supplier Part Number 1: RMCF0603FT180KCT-ND; Supplier Device Package: 0603; Supplier 1: DigiKey; Size / Dimension: 0.061" L x 0.031" W (1.55mm x 0.80mm); Series: RMCF; Resistance: 180 kOhms; Ratings: AEC-Q200; Price: 0.10; Part Status: Active; Part Number: RMCF0603FT180K; PartId: 16499; Packaging: CutTape; Package / Case: 0603 (1608 Metric); Operating Temperature: -55°C ~ 155°C; Number of Terminations: 2; Minimum Order: 1; Lifecycle Status: Active; Library Name: Celestial Altium Library - altium_library.DbLib; LastUpdated: 9/2/2020 1:10:00 AM; Height - Seated (Max): 0.022" (0.55mm); Features: Automotive AEC-Q200; Device Package: 0603 (1608 Metric); Composition: Thick Film; ComponentLink2URL: http://digikey.com/product-detail/en/stackpole-electronics-inc/RMCF0603FT180K/RMCF0603FT180KCT-ND/5049744; ComponentLink2Description: DigiKey Link; ComponentLink1URL: https://www.seielect.com/catalog/sei-rmcf_rmcp.pdf; ComponentLink1Description: Datasheet; Component Kind: Standard
R25 = ERJ-PB3B7151V: RES SMD 7.15K OHM 0.1% 1/5W 0603; Manufacturer: Panasonic Electronic Components; Temperature Coefficient: ±50ppm/°C; Power (Watts): 0.2W, 1/5W; Database Table Name: Resistors - Surface Mount - 0603; Value: 7.15 kOhms; Tolerance: ±0.1%; Supplier Part Number 1: P20070CT-ND; Supplier Device Package: 0603; Supplier 1: DigiKey; Size / Dimension: 0.063" L x 0.031" W (1.60mm x 0.80mm); Series: ERJ; Resistance: 7.15 kOhms; Ratings: AEC-Q200; Price: 0.03; Part Status: Active; Part Number: ERJ-PB3B7151V; PartId: 6380; Packaging: TapeAndReel; Package / Case: 0603 (1608 Metric); Operating Temperature: -55°C ~ 155°C; Number of Terminations: 2; Minimum Order: 1; Lifecycle Status: Active; Library Name: Celestial Altium Library - altium_library.DbLib; LastUpdated: 9/2/2020 1:10:22 AM; Height - Seated (Max): 0.022" (0.55mm); Features: Automotive AEC-Q200, Pulse Withstanding; Device Package: 0603 (1608 Metric); Composition: Thick Film; ComponentLink2URL: http://digikey.com/product-detail/en/panasonic-electronic-components/ERJ-PB3B7151V/P20070CT-ND/6214325; ComponentLink2Description: DigiKey Link; ComponentLink1URL: https://industrial.panasonic.com/cdbs/www-data/pdf/RDM0000/AOA0000C328.pdf; ComponentLink1Description: Datasheet; Component Kind: Standard
R26 = RMCF0603FG47K5: RES 47.5K OHM 1% 1/10W 0603; Manufacturer: Stackpole Electronics Inc; Temperature Coefficient: ±100ppm/°C; Power (Watts): 0.1W, 1/10W; Database Table Name: Resistors - Surface Mount - 0603; Value: 47.5 kOhms; Tolerance: ±1%; Supplier Part Number 1: RMCF0603FG47K5CT-ND; Supplier Device Package: 0603; Supplier 1: DigiKey; Size / Dimension: 0.061" L x 0.031" W (1.55mm x 0.80mm); Series: RMCF; Resistance: 47.5 kOhms; Ratings: AEC-Q200; Price: 0.10; Part Status: Active; Part Number: RMCF0603FG47K5; PartId: 25458; Packaging: CutTape; Package / Case: 0603 (1608 Metric); Operating Temperature: -55°C ~ 155°C; Number of Terminations: 2; Minimum Order: 1; Lifecycle Status: Active; Library Name: Celestial Altium Library - altium_library.DbLib; LastUpdated: 9/2/2020 1:09:32 AM; Height - Seated (Max): 0.022" (0.55mm); Features: Automotive AEC-Q200; Device Package: 0603 (1608 Metric); Composition: Thick Film; ComponentLink2URL: http://digikey.com/product-detail/en/stackpole-electronics-inc/RMCF0603FG47K5/RMCF0603FG47K5CT-ND/4425046; ComponentLink2Description: DigiKey Link; ComponentLink1URL: https://www.seielect.com/catalog/sei-packaging.pdf; ComponentLink1Description: Datasheet; Component Kind: Standard
R27 = RMCF0603FG150K: RES 150K OHM 1% 1/10W 0603; Manufacturer: Stackpole Electronics Inc; Temperature Coefficient: ±100ppm/°C; Power (Watts): 0.1W, 1/10W; Database Table Name: Resistors - Surface Mount - 0603; Value: 150 kOhms; Tolerance: ±1%; Supplier Part Number 1: RMCF0603FG150KCT-ND; Supplier Device Package: 0603; Supplier 1: DigiKey; Size / Dimension: 0.061" L x 0.031" W (1.55mm x 0.80mm); Series: RMCF; Resistance: 150 kOhms; Ratings: AEC-Q200; Price: 0.10; Part Status: Active; Part Number: RMCF0603FG150K; PartId: 13982; Packaging: CutTape; Package / Case: 0603 (1608 Metric); Operating Temperature: -55°C ~ 155°C; Number of Terminations: 2; Minimum Order: 1; Lifecycle Status: Active; Library Name: Celestial Altium Library - altium_library.DbLib; LastUpdated: 9/2/2020 1:10:05 AM; Height - Seated (Max): 0.022" (0.55mm); Features: Automotive AEC-Q200; Device Package: 0603 (1608 Metric); Composition: Thick Film; ComponentLink2URL: http://digikey.com/product-detail/en/stackpole-electronics-inc/RMCF0603FG150K/RMCF0603FG150KCT-ND/4425034; ComponentLink2Description: DigiKey Link; ComponentLink1URL: https://www.seielect.com/catalog/sei-rmcf_rmcp.pdf; ComponentLink1Description: Datasheet; Component Kind: Standard
R28 = RMCF0603FT63K4: RES 63.4K OHM 1% 1/10W 0603; Manufacturer: Stackpole Electronics Inc; Temperature Coefficient: ±100ppm/°C; Power (Watts): 0.1W, 1/10W; Database Table Name: Resistors - Surface Mount - 0603; Value: 63.4 kOhms; Tolerance: ±1%; Supplier Part Number 1: RMCF0603FT63K4CT-ND; Supplier Device Package: 0603; Supplier 1: DigiKey; Size / Dimension: 0.061" L x 0.031" W (1.55mm x 0.80mm); Series: RMCF; Resistance: 63.4 kOhms; Ratings: AEC-Q200; Price: 0.10; Part Status: Active; Part Number: RMCF0603FT63K4; PartId: 8895; Packaging: CutTape; Package / Case: 0603 (1608 Metric); Operating Temperature: -55°C ~ 155°C; Number of Terminations: 2; Minimum Order: 1; Lifecycle Status: Active; Library Name: Celestial Altium Library - altium_library.DbLib; LastUpdated: 9/2/2020 1:10:16 AM; Height - Seated (Max): 0.022" (0.55mm); Features: Automotive AEC-Q200; Device Package: 0603 (1608 Metric); Composition: Thick Film; ComponentLink2URL: http://digikey.com/product-detail/en/stackpole-electronics-inc/RMCF0603FT63K4/RMCF0603FT63K4CT-ND/2418113; ComponentLink2Description: DigiKey Link; ComponentLink1URL: https://www.seielect.com/catalog/sei-rmcf_rmcp.pdf; ComponentLink1Description: Datasheet; Component Kind: Standard
R31 = CRCW060350R0FKEA: RES SMD 50 OHM 1% 1/10W 0603; Manufacturer: Vishay Dale; Temperature Coefficient: ±100ppm/°C; Power (Watts): 0.1W, 1/10W; Database Table Name: Resistors - Surface Mount - 0603; Value: 50 Ohms; Tolerance: ±1%; Supplier Part Number 1: 541-3318-1-ND; Supplier Device Package: 0603; Supplier 1: DigiKey; Size / Dimension: 0.061" L x 0.033" W (1.55mm x 0.85mm); Series: CRCW; Resistance: 50 Ohms; Ratings: AEC-Q200; Price: 0.10; Part Status: Active; Part Number: CRCW060350R0FKEA; PartId: 23780; Packaging: CutTape; Package / Case: 0603 (1608 Metric); Operating Temperature: -55°C ~ 155°C; Number of Terminations: 2; Minimum Order: 1; Lifecycle Status: Active; Library Name: Celestial Altium Library - altium_library.DbLib; LastUpdated: 9/2/2020 1:09:40 AM; Height - Seated (Max): 0.020" (0.50mm); Features: Automotive AEC-Q200; Device Package: 0603 (1608 Metric); Composition: Thick Film; ComponentLink2URL: http://digikey.com/product-detail/en/vishay-dale/CRCW060350R0FKEA/541-3318-1-ND/6684924; ComponentLink2Description: DigiKey Link; ComponentLink1URL: http://www.vishay.com/docs/20035/dcrcwe3.pdf; ComponentLink1Description: Datasheet; Component Kind: Standard
R32 = ERJ-PB3B3242V: RES SMD 32.4K OHM 0.1% 1/5W 0603; Manufacturer: Panasonic Electronic Components; Temperature Coefficient: ±50ppm/°C; Power (Watts): 0.2W, 1/5W; Database Table Name: Resistors - Surface Mount - 0603; Value: 32.4 kOhms; Tolerance: ±0.1%; Supplier Part Number 1: P20142CT-ND; Supplier Device Package: 0603; Supplier 1: DigiKey; Size / Dimension: 0.063" L x 0.031" W (1.60mm x 0.80mm); Series: ERJ; Resistance: 32.4 kOhms; Ratings: AEC-Q200; Price: 0.03; Part Status: Active; Part Number: ERJ-PB3B3242V; PartId: 13586; Packaging: TapeAndReel; Package / Case: 0603 (1608 Metric); Operating Temperature: -55°C ~ 155°C; Number of Terminations: 2; Minimum Order: 1; Lifecycle Status: Active; Library Name: Celestial Altium Library - altium_library.DbLib; LastUpdated: 9/2/2020 1:10:06 AM; Height - Seated (Max): 0.022" (0.55mm); Features: Automotive AEC-Q200, Pulse Withstanding; Device Package: 0603 (1608 Metric); Composition: Thick Film; ComponentLink2URL: http://digikey.com/product-detail/en/panasonic-electronic-components/ERJ-PB3B3242V/P20142CT-ND/6214397; ComponentLink2Description: DigiKey Link; ComponentLink1URL: https://industrial.panasonic.com/cdbs/www-data/pdf/RDM0000/AOA0000C328.pdf; ComponentLink1Description: Datasheet; Component Kind: Standard
R89 = RNCP0603FTD1K00: RES 1K OHM 1% 1/8W 0603; Manufacturer: Stackpole Electronics Inc; Temperature Coefficient: ±100ppm/°C; Power (Watts): 0.125W, 1/8W; Database Table Name: Resistors - Surface Mount - 0603; Value: 1 kOhms; Tolerance: ±1%; Supplier Part Number 1: RNCP0603FTD1K00CT-ND; Supplier Device Package: 0603; Supplier 1: DigiKey; Size / Dimension: 0.059" L x 0.031" W (1.50mm x 0.80mm); Series: RNCP; Resistance: 1 kOhms; Price: 0.01; Part Status: Active; Part Number: RNCP0603FTD1K00; PartId: 5694; Packaging: TapeAndReel; Package / Case: 0603 (1608 Metric); Operating Temperature: -55°C ~ 155°C; Number of Terminations: 2; Minimum Order: 1; Lifecycle Status: Active; Library Name: Celestial Altium Library - altium_library.DbLib; LastUpdated: 9/2/2020 1:10:23 AM; Height - Seated (Max): 0.020" (0.50mm); Features: Anti-Sulfur, Moisture Resistant; Device Package: 0603 (1608 Metric); Composition: Thin Film; ComponentLink2URL: http://digikey.com/product-detail/en/stackpole-electronics-inc/RNCP0603FTD1K00/RNCP0603FTD1K00CT-ND/2240445; ComponentLink2Description: DigiKey Link; ComponentLink1URL: https://www.seielect.com/catalog/sei-rncp.pdf; ComponentLink1Description: Datasheet; Component Kind: Standard
U4 = CMP-0323-00129-3: Buck Step Down Regulator with 3 to 17 V Input and 3.3 V Output, -40 to 85 degC, 16-Pin QFN (RGT), Green (RoHS & no Sb/Br); Manufacturer: Texas Instruments; Vout(Min)(V): 3.3; Vin(Min)(V): 3; Vin(Max)(V): 17; Synchronous Rectification: TRUE; Switch Current Limit(Typ)(A): 1.7; SubFamily: Step-Down Regulator; Regulated Outputs(#): 1; Rating: Catalog; Iq(Typ)(mA): 0.017; Duty Cycle(Max)(%): 100; Vout(Max)(V): 3.3; Topology: Buck; Preset Vout(V): 3.3; PartNumber: TPS62152RGTT; PackageVersion: revH, Oct-2011; PackageReference: RGT0016C; PackageDescription: 16-Pin Plastic Quad Flat No-Lead, 3 x 3 mm Body, 0.5 mm Pitch, 1.7 x 1.7 mm Exposed Pad; Mounting Technology: Surface Mount; Library Name: Altium Content Vault; Iout(Max)(A): 1; Eco-Plan: Green (RoHS & no Sb/Br); DatasheetVersion: SLVSAL5; ComponentLink3URL: Samples URL:http://www.ti.com/product/tps54611#samples; ComponentLink3Description: URL Link1; ComponentLink2URL: http://www.ti.com/litv/pdf/mpqf119g; ComponentLink2Description: Package Specification; ComponentLink1URL: http://www.ti.com/general/docs/lit/getliterature.tsp?genericPartNumber=TPS62152&fileType=pdf; ComponentLink1Description: Datasheet; Component Kind: Standard; Code_JEDEC: MO-220
U5 U7 = CMP-0704-00041-2: Zero-Drift, Bi-directional Current / Power Monitor, 3 to 5.5 V, -40 to 125 degC, 8-pin SOP (DCN8), Green (RoHS & no Sb/Br); Manufacturer: Texas Instruments; Vs(Min)(V): 3; Vs(Max)(V): 5.5; SubFamily: Current Shunt Monitor; Small-Signal Bandwidth(Typ)(MHz): 0.011; Rating: Catalog; Quiescent Current (+/-)(Typ)(mA): 0.7; Input Offset (+/-)(Max)(uV): 100; Gain(V/V): 1, 0.5, 0.25, 0.125; Common Mode Range(Min)(V): 0; Common Mode Range(Max)(V): 26; PartNumber: INA219AIDCNR; PackageVersion: 4202106/D, 11/2009; PackageReference: DCN0008A; PackageDescription: 8-Pin Plastic Small Outline, Body 1.6 x 2.9 mm, Pitch 0.65 mm; Mounting Technology: Surface Mount; Library Name: Altium Content Vault; Eco-Plan: Green (RoHS & no Sb/Br); DatasheetVersion: SBOS448F; ComponentLink3URL: http://www.ti.com/lit/ml/mpds099c/mpds099c.pdf; ComponentLink3Description: Package Specification; ComponentLink2URL: http://www.ti.com/general/docs/lit/getliterature.tsp?genericPartNumber=INA219&fileType=pdf; ComponentLink2Description: Datasheet; ComponentLink1URL: http://www.ti.com/; ComponentLink1Description: Manufacturer URL; Component Kind: Standard; Code_JEDEC: MO-178; CMRR(Min)(dB): 100
U6 = CMP-0323-00124-3: Buck Step Down Regulator with 3 to 17 V Input and 1.8 V Output, -40 to 85 degC, 16-Pin QFN (RGT), Green (RoHS & no Sb/Br); Manufacturer: Texas Instruments; Vout(Min)(V): 1.8; Vin(Min)(V): 3; Vin(Max)(V): 17; Synchronous Rectification: TRUE; Switch Current Limit(Typ)(A): 1.7; SubFamily: Step-Down Regulator; Regulated Outputs(#): 1; Rating: Catalog; Iq(Typ)(mA): 0.017; Duty Cycle(Max)(%): 90; Vout(Max)(V): 1.8; Topology: Buck; Preset Vout(V): 1.8; PartNumber: TPS62151RGTT; PackageVersion: revH, Oct-2011; PackageReference: RGT0016C; PackageDescription: 16-Pin Plastic Quad Flat No-Lead, 3 x 3 mm Body, 0.5 mm Pitch, 1.7 x 1.7 mm Exposed Pad; Mounting Technology: Surface Mount; Library Name: Altium Content Vault; Iout(Max)(A): 1; Eco-Plan: Green (RoHS & no Sb/Br); DatasheetVersion: SLVSAL5; ComponentLink3URL: Samples URL:http://www.ti.com/product/tps54611#samples; ComponentLink3Description: URL Link1; ComponentLink2URL: http://www.ti.com/litv/pdf/mpqf119g; ComponentLink2Description: Package Specification; ComponentLink1URL: http://www.ti.com/general/docs/lit/getliterature.tsp?genericPartNumber=TPS62151&fileType=pdf; ComponentLink1Description: Datasheet; Component Kind: Standard; Code_JEDEC: MO-220
TP3-TP8 = 2.5mm_SMD_TestPoint: Component Kind: Standard; Library Name: HAB_Components.IntLib
U2 = SCH - POWER - EFUSE - TPS25942A: Supplier 1: Digi-Key; Component Kind: Standard; Library Name: HAB_ICs.SchLib
